# Altium schematic sheet USB.SchDoc(USB)
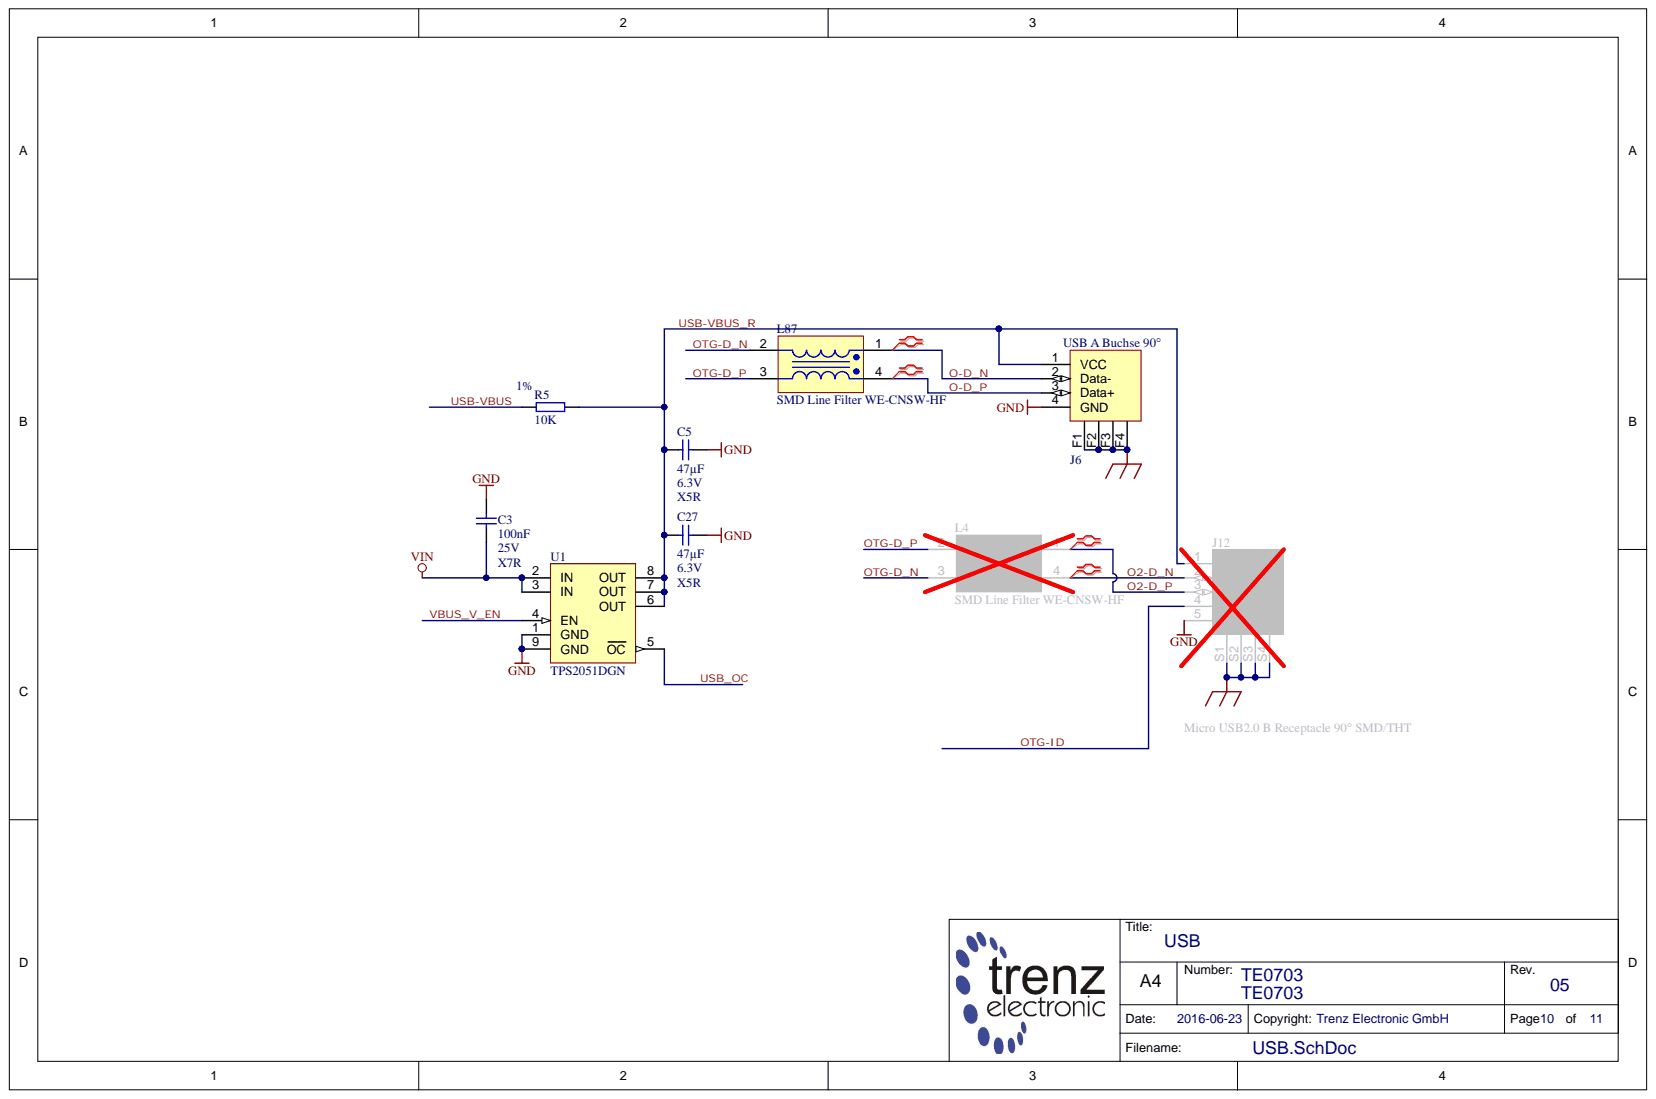
[diagram p0: USB.SchDoc]

## Parts
C3  100nF  Voltage: 25V  [CAPC1005X55N]
C5  47µF  Voltage: 6.3V  [CAPC2012X135N]
C27  47µF  Technology: X5R  Voltage: 6.3V  [CAPC2012X135N]
J6  USB A Buchse 90°  [73725-0110BLF]
J12  Micro USB2.0 B Receptacle 90° SMD/THT  [629105150521]
L4  SMD Line Filter WE-CNSW-HF  [WE-CNSW-HF]
L87  SMD Line Filter WE-CNSW-HF  [WE-CNSW-HF]
R5  10K  Tolerance: 1%  [RESC1005X40N]
U1  TPS2051DGN  [SOP65P488X107-9N-TI]

## Nets
FGND: J6.F1 J6.F2 J6.F3 J6.F4 J12.S1 J12.S2 J12.S3 J12.S4
GND: C3.2 C5.2 C27.2 J6.4(GND) J12.5(GND) U1.1(GND) U1.9(GND)
VIN: C3.1 U1.2(IN) U1.3(IN)
O2-D_N: J12.2(D-) L4.4
O2-D_P: J12.3(D+) L4.1
O-D_N: J6.2(Data-) L87.1
O-D_P: J6.3(Data+) L87.4
OTG-D_N: L4.3 L87.2
OTG-D_P: L4.2 L87.3
OTG-ID: J12.4(ID)
USB-VBUS: R5.1
USB-VBUS_R: C5.1 C27.1 J6.1(VCC) J12.1(Vbus) R5.2 U1.6(OUT) U1.7(OUT) U1.8(OUT)
USB_OC: U1.5(OC)
VBUS_V_EN: U1.4(EN)

## Library data of the parts
C3 = 22472: KON 100nF 25V X5R SMD; Database Table Name: C_1005_0402; Mixed: SMT; Preferred: Yes; Value: 100n; AN: 22472; Case: 1005 (0402); Component Kind: Standard; Library Name: TE.DbLib; Technology: X7R
C5 C27 = 24718: KON 47µF 6,3V  X5R SMD 20%; Database Table Name: C_2012_0805; Mixed: SMT; Preferred: Yes; Value: 47u; AN: 24718; Case: 2012 (0805); Component Kind: Standard; Library Name: TE.DbLib; Tolerance: 20%
  C5: Technology: X5R
J6 = 24948: Database Table Name: CON_IO; Mixed: THT; Trenz-Stock: Yes; AN: 24948; Component Kind: Standard; Description: USB A Buchse 90°; H-ART: 73725-0110BLF; Library Name: TE.DbLib; HelpURL: B:\AD\adlib\datasheet\73725
J12 = 27235: Database Table Name: CON_IO; Mixed: SMT; AN: 27235; Component Kind: Standard; Description: Micro USB2.0 B Receptacle 90° SMD/THT; H-ART: 629105150521; Library Name: TE.DbLib; HelpURL: ‪B:\AD\adlib\datasheet\629105150521
L4 L87 = 24514: Stromkompensierter SMD Line Filter HF 320mA 0,24Ohm 50V; Database Table Name: L_SMD; H-ART: 744233670; Mixed: SMT; Preferred: Yes; AN: 24514; Component Kind: Standard; Library Name: TE.DbLib; Technology: 0.24Ohm; Trenz-Stock: Yes; Voltage: 320mA; HelpURL: B:\AD\adlib\datasheet\WE-CNSW HF
R5 = 22750: WID SMD 10K 1% 0,063W; Database Table Name: R_1005_0402; Mixed: SMT; Preferred: Yes; AN: 22750; Case: 1005 (0402); Component Kind: Standard; Library Name: TE.DbLib; Technology: TK100; Value: 10K
U1 = 25167: TPS2051BDGN; Database Table Name: SWITCH; Mixed: SMT; Preferred: Yes; AN: 25167; Component Kind: Standard; H-ART: TPS2051BDGN; Library Name: TE.DbLib; Trenz-Stock: Yes; HelpURL: B:\AD\adlib\datasheet\TPS2051BDGN
